# Altium schematic sheet POWER_SWITCHING.SchDoc
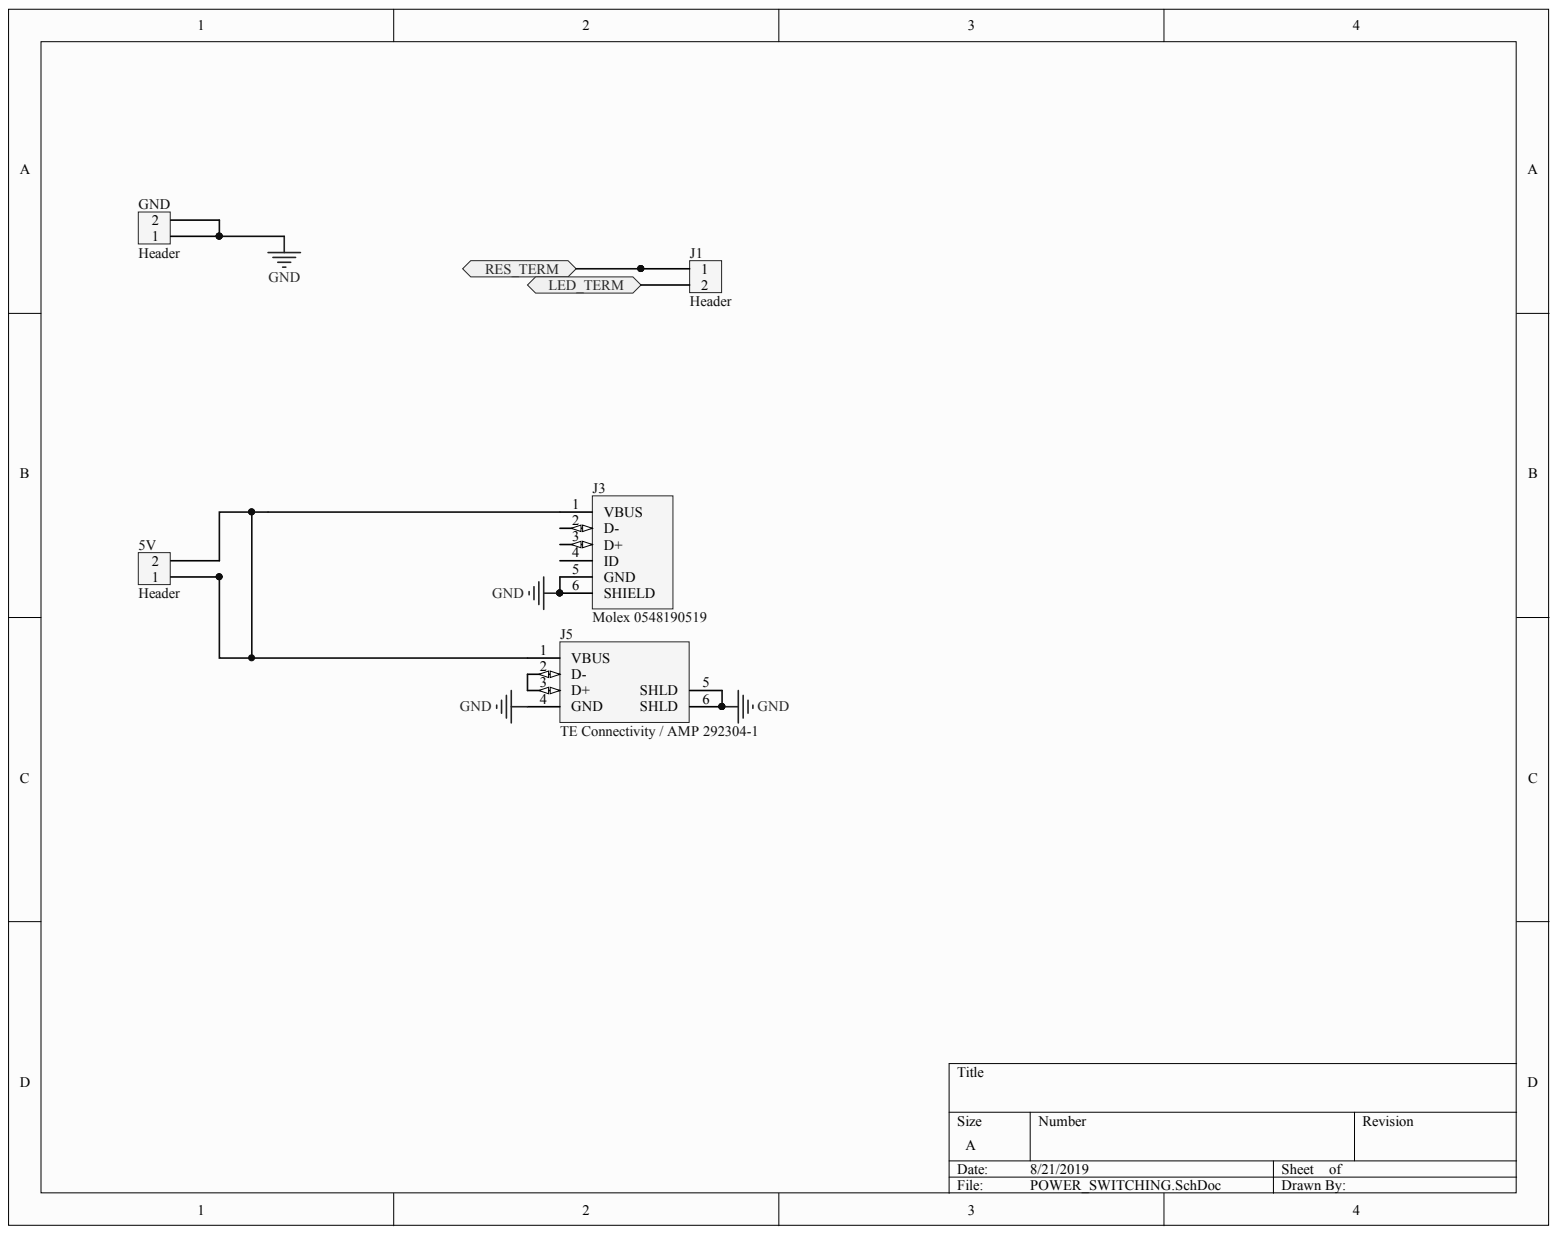
[diagram p0: POWER_SWITCHING.SchDoc]

## Parts
5V  Header  [PCB-header]
GND  Header  [PCB-header]
J1  Header  [PCB-header]
J3  Molex 0548190519  Manufacturer Part Number: 0548190519  [PCB-2328442-1]
J5  TE Connectivity / AMP 292304-1  Manufacturer Part Number: 292304-1  [PCB-185gqpcdnvgdncegx4qx-1]

## Ports
LED_TERM, RES_TERM

## Nets
GND: GND.1 GND.2 J3.5(GND) J3.6(SHIELD) J5.4(GND) J5.5(SHLD) J5.6(SHLD)
LED_TERM: J1.2 ; port LED_TERM
RES_TERM: J1.1 ; port RES_TERM
Net5V_1: 5V.1 5V.2 J3.1(VBUS) J5.1(VBUS)
NetJ5_2: J5.2(D-) J5.3(D+)

Not connected: J3 2(D-) 3(D+) 4(ID)

## Library data of the parts
5V GND J1 = CMP-74e0bf2ff245ab27-10: Connector, Through Hole, Header, 2, 2.54 mm 2pin; Component Kind: Standard; Library Name: CommunityVault; Orientation: Vertical; RoHS: Compliant
J3 = CMP-2328442-4: Conn Mini USB Type B F 5 POS 1.6mm Solder RA Thru-Hole 5 Terminal 1 Port Tray; Body Orientation: Right Angle; Gender: RCP; Number of Contacts: 5; CiivaID: 2328442; Ciiva URL: https://ciiva.com/part/0548190519-2328442; Component Kind: Standard; Library Name: CommunityVault; Mounting: Through Hole; Packaging: Trays; Pitch: 1.6 mm; Termination Method: Solder; Type: USB
J5 = CMP-a9ce629d318a7609-5: Surface Mount Right Angle USB B Connector; Current Rating: 1.00 A; ELV Compliant: Compliant; Gender: Female; Insulation Resistance: 1.00 GΩ; Lead-Free Status: Lead Free; Number of Contacts: 4; Number of Mating Cycles: 1500; Voltage Rating (AC): 30.0 V; Component Kind: Standard; Contact Material: Copper Alloy; Contact Plating: Gold, Gold over Nickel, Gold Flash, Gold Flash over Nickel; Flammability Rating: UL 94 V-0; Housing Color: Black; Housing Material: Thermoplastic; Library Name: CommunityVault; Lifecycle Status: Active; Mounting Style: Through Hole, Horizontal; Number of Positions: 4; OctopartID: a9ce629d318a7609; Octopart URL: http://octopart.com/292304-1-te+connectivity+%2F+amp-39435554; Orientation: Right Angle; Packaging: Tray; REACH SVHC Compliance: No SVHC; RoHS: Compliant; Size-Height: 11.5 mm; Size-Length: 15.7 mm; Size-Width: 15.7 mm
